# Altium schematic sheet CH342F.SchDoc
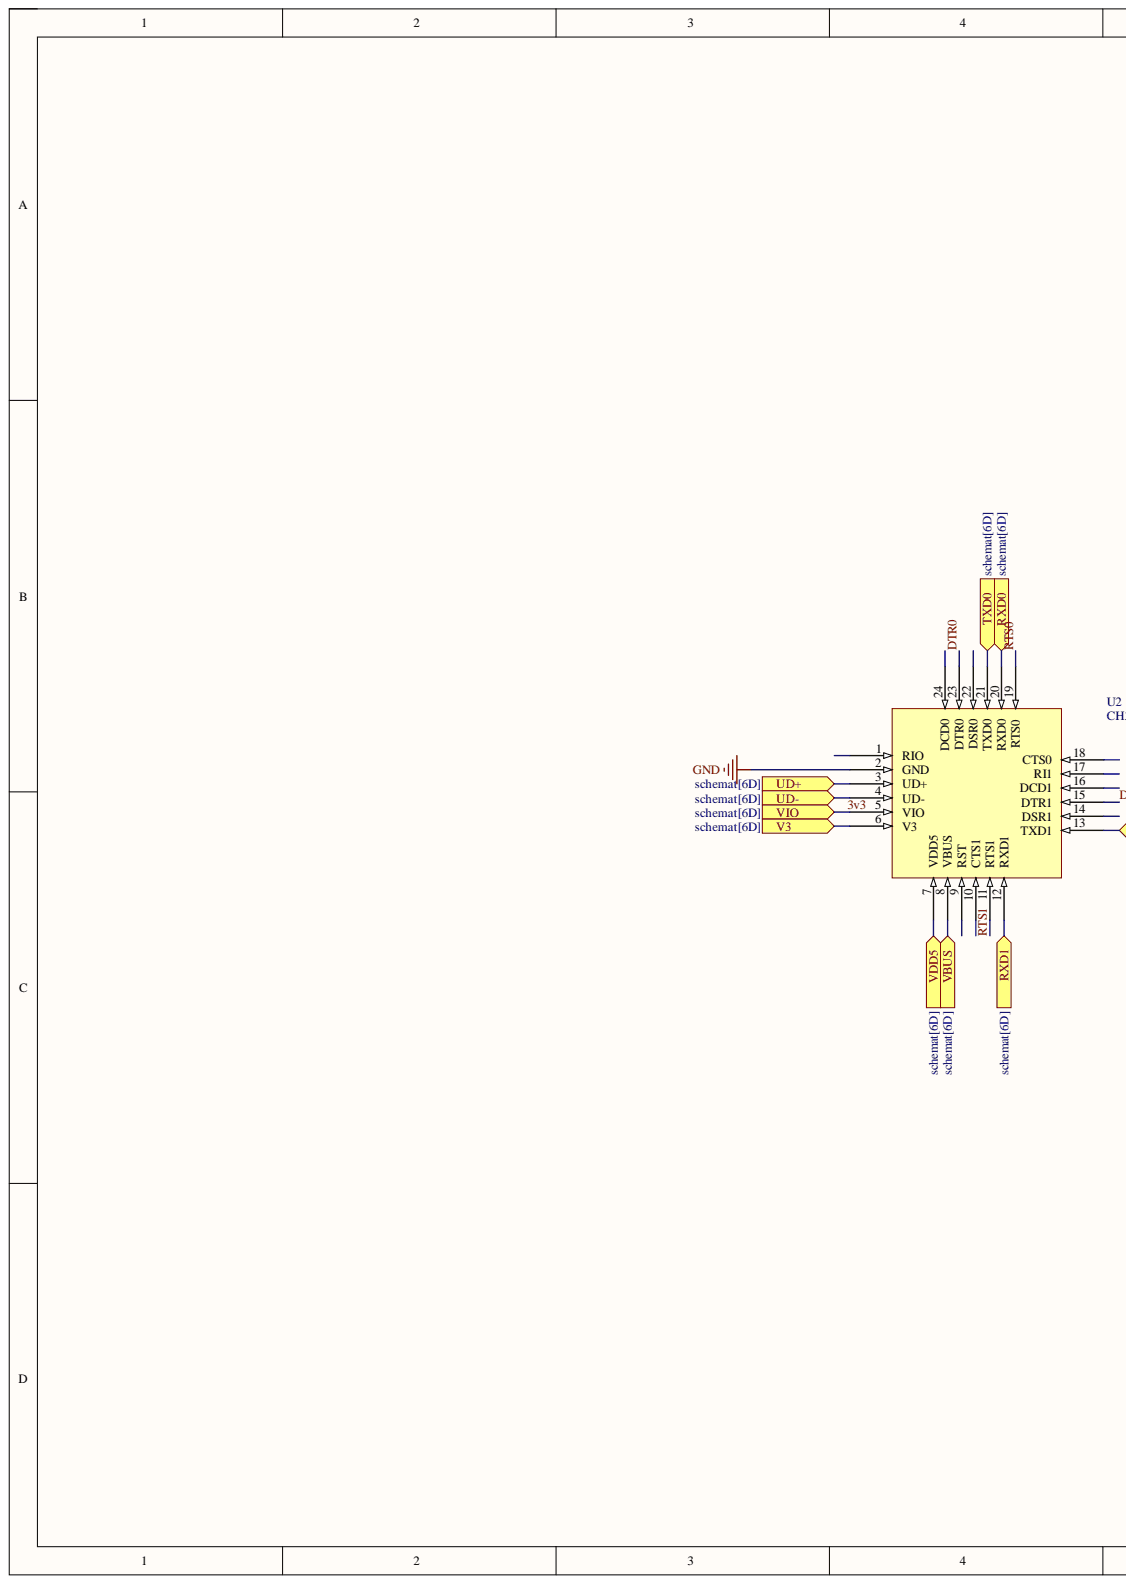
[diagram p0: CH342F.SchDoc, left]
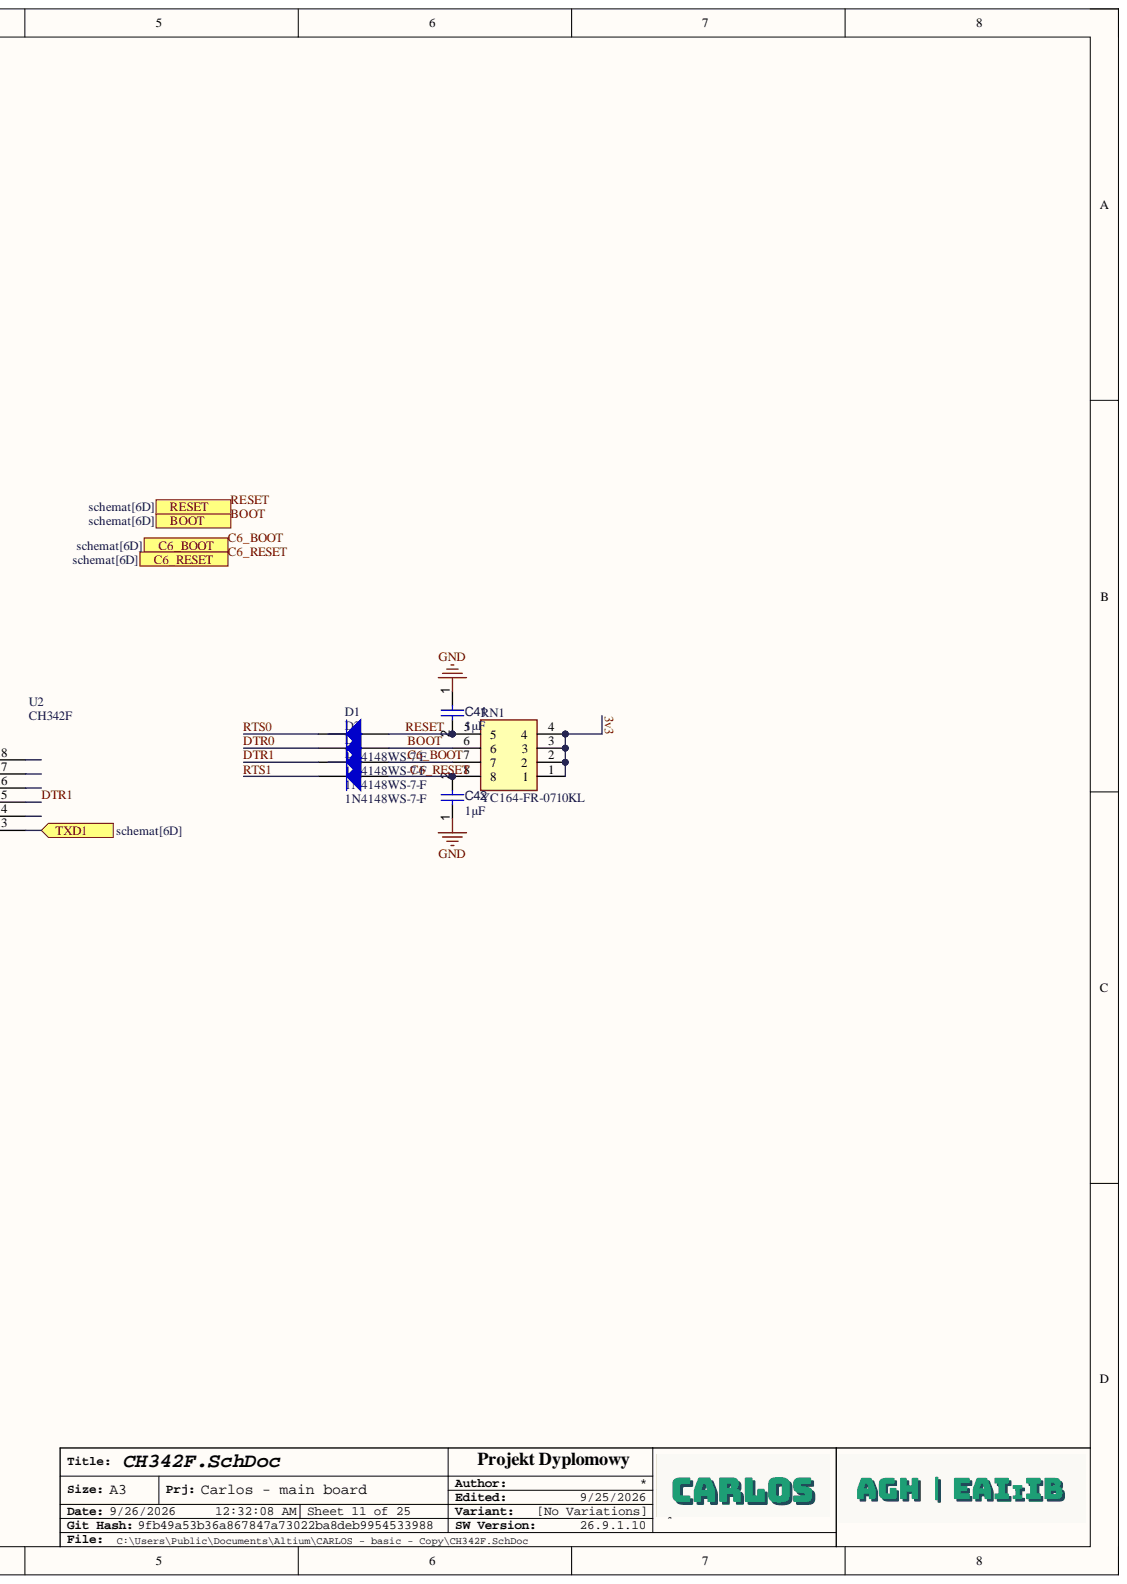
[diagram p1: CH342F.SchDoc, right]

## Parts
C41  value 1µF  [CAPC1608X90X40LL10T20]  (hidden comment: Capacitor 1 uF +/- 10% 25 V 0603)
C42  value 1µF  [CAPC1608X90X40LL10T20]  (hidden comment: Capacitor 1 uF +/- 10% 25 V 0603)
D1  1N4148WS-7-F  [DIOD-SOD323-2-A_V]
D2  1N4148WS-7-F  [DIOD-SOD323-2-A_V]
D3  1N4148WS-7-F  [DIOD-SOD323-2-A_V]
D5  1N4148WS-7-F  [DIOD-SOD323-2-A_V]
RN1  YC164-FR-0710KL  [YC164JR07220RL]
U2  CH342F  [CH342F-Footprint-1]

## Ports
BOOT, C6_BOOT, C6_RESET, RESET, RXD0, RXD1, TXD0, TXD1, UD+, UD-, V3, VBUS, VDD5, VIO

## Nets
GND: C41.1 C42.1 U2.2(GND)
3v3: RN1.1 RN1.2 RN1.3 RN1.4 U2.5(VIO) ; port VIO
BOOT: D2.1 RN1.6 ; port BOOT
C6_BOOT: D3.1 RN1.7 ; port C6_BOOT
C6_RESET: C42.2 D5.1 RN1.8 ; port C6_RESET
DTR0: D2.2 U2.23(DTR0)
DTR1: D3.2 U2.15(DTR1)
RESET: C41.2 D1.1 RN1.5 ; port RESET
RTS0: D1.2 U2.19(RTS0)
RTS1: D5.2 U2.11(RTS1)
RXD0: U2.20(RXD0) ; port RXD0
RXD1: U2.12(RXD1) ; port RXD1
TXD0: U2.21(TXD0) ; port TXD0
TXD1: U2.13(TXD1) ; port TXD1
V3: U2.6(V3) ; port V3
VBUS: U2.8(VBUS) ; port VBUS
VDD5: U2.7(VDD5) ; port VDD5
NetU2_3: U2.3(UD+)
NetU2_4: U2.4(UD-)

Not connected: U2 1(RIO) 9(RST) 10(CTS1) 14(DSR1) 16(DCD1) 17(RI1) 18(CTS0) 22(DSR0) 24(DCD0)

## Library data of the parts
C41 C42 = CMP-001-00005-6: Chip Capacitor, 1 uF, +/- 10%, 25 V, 0603 (1608 Metric); Capacitor Type: Ceramic; Voltage Rating: 25V; Case/Package: 0603; Component Kind: Standard; Max Operating Temperature: 125°C; Min Operating Temperature: -55°C; Mounting Technology: SM; Pins: 2; RoHS Compliant: True; Tolerance: 10%; Library Name: Jakub Sikora
D1-D3 D5 = CMP-004-00002-2: Fast Switching Diode, 75 V, 0.3 A, -65 to 150 degC, 2-Pin SOD323, RoHS, Tape and Reel; Manufacturer: Diodes Inc; Elements: 1; Forward Current: 300mA; Forward Voltage: 1.25V; Max Surge Current: 2A; Peak Reverse Current: 1uA; Recovery Time: 4ns; Case/Package: SOD; Component Kind: Standard; Datasheet URL: http://www.diodes.com/datasheets/ds30097.pdf; Datasheet Version: Rev. 25-2, 03/2016; Manufacturer URL: http://www.diodes.com/; Max Operating Temperature: 150°C; Min Operating Temperature: -65°C; Mounting Technology: SM; Package Description: 2-Pin Small Outline Diode, Body 1.7 x 1.3 mm; Package Quantity: 3000; Package Reference: SOD323-2; Packaging: TapeandReel; Pins: 2; RoHS Compliant: True; Library Name: Jakub Sikora
RN1 = YC164-FR-0710KL: Resistor Network; Manufacturer_Name: Yageo Group; Arrow Part Number: YC164-FR-0710KL; Arrow Price/Stock: https://www.arrow.com/en/products/yc164-fr-0710kl/yageo?region=nac; Component Kind: Standard; Datasheet Link: https://www.mouser.com/datasheet/2/447/PYu-YC_TC_group_51_RoHS_L_7-1313905.pdf; Height: 0mm; Manufacturer_Part_Number: YC164-FR-0710KL; Mouser Part Number: 603-YC164-FR-0710KL; Mouser Price/Stock: https://www.mouser.co.uk/ProductDetail/Yageo/YC164-FR-0710KL/?qs=8cPjvKtxWv4rLfyjGsoQQw%3D%3D
U2 = CMP-015-00008-2: USB to Dual Serial Ports Chip; Component Kind: Standard; Library Name: Jakub Sikora
